# Altium schematic sheet Prometheus.SchDoc
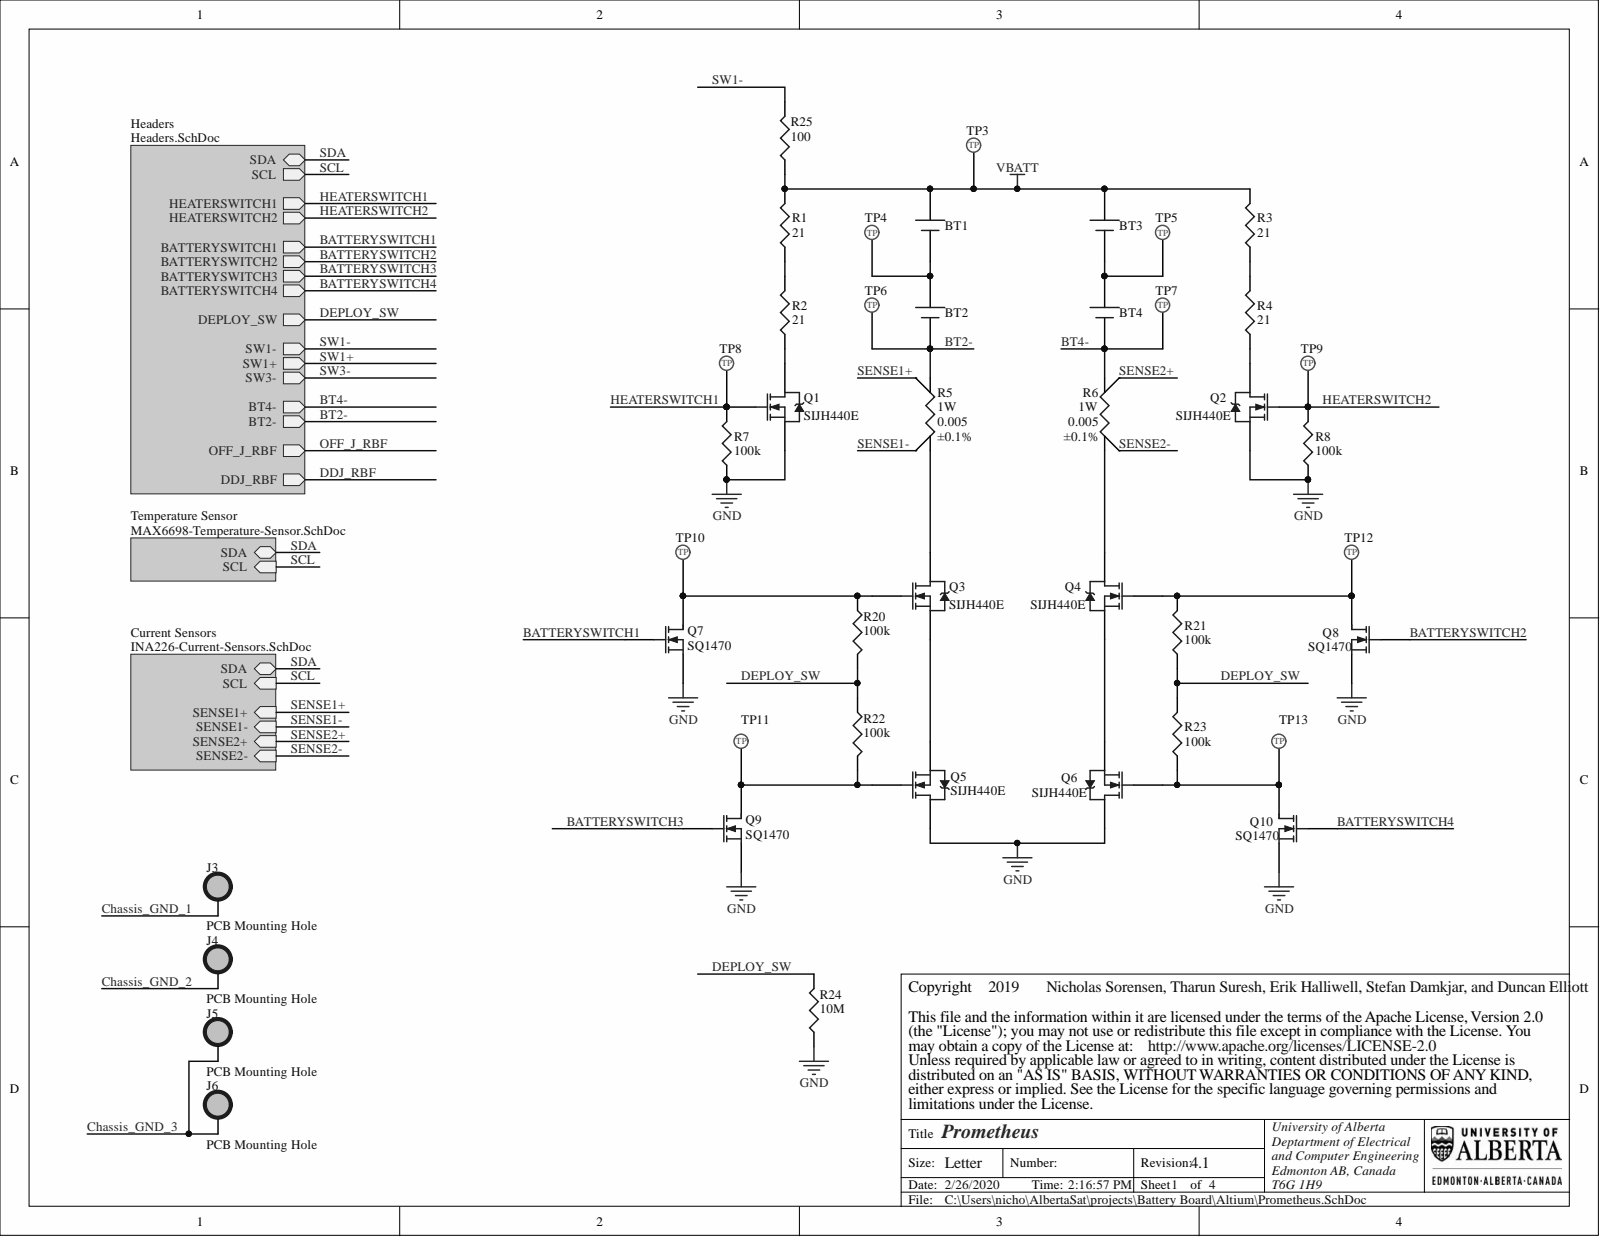
[diagram p0: Prometheus.SchDoc]

## Parts
BT1  NCR18650PF  [ORB-PROTECTED18650]  (value not drawn)
BT2  NCR18650PF  [ORB-PROTECTED18650]  (value not drawn)
BT3  NCR18650PF  [ORB-PROTECTED18650]  (value not drawn)
BT4  NCR18650PF  [ORB-PROTECTED18650]  (value not drawn)
J3  PCB Mounting Hole  [PCB Mounting Hole]
J4  PCB Mounting Hole  [PCB Mounting Hole]
J5  PCB Mounting Hole  [PCB Mounting Hole]
J6  PCB Mounting Hole  [PCB Mounting Hole]
Q1  SIJH440E  [VSH-POWERPAK8X8L]
Q2  SIJH440E  [VSH-POWERPAK8X8L]
Q3  SIJH440E  [VSH-POWERPAK8X8L]
Q4  SIJH440E  [VSH-POWERPAK8X8L]
Q5  SIJH440E  [VSH-POWERPAK8X8L]
Q6  SIJH440E  [VSH-POWERPAK8X8L]
Q7  SQ1470  [VSH-SQ1470AEH]
Q8  SQ1470  [VSH-SQ1470AEH]
Q9  SQ1470  [VSH-SQ1470AEH]
Q10  SQ1470  [VSH-SQ1470AEH]
R1  value 21  [VSH-CPF1]  (hidden comment: Res3)
R2  value 21  [VSH-CPF1]  (hidden comment: Res3)
R3  value 21  [VSH-CPF1]  (hidden comment: Res3)
R4  value 21  [VSH-CPF1]  (hidden comment: Res3)
R5  value 0.005  Power - Rated: 1W  Tolerance: ±0.1%  [VSH-2512R4B]  (hidden comment: Res Sense)
R6  value 0.005  Power - Rated: 1W  Tolerance: ±0.1%  [VSH-2512R4B]  (hidden comment: Res Sense)
R7  value 100k  [PANA-ERJ6EN]  (hidden comment: Res3)
R8  value 100k  [PANA-ERJ6EN]  (hidden comment: Res3)
R20  value 100k  [PANA-ERJ6EN]  (hidden comment: Res3)
R21  value 100k  [PANA-ERJ6EN]  (hidden comment: Res3)
R22  value 100k  [PANA-ERJ6EN]  (hidden comment: Res3)
R23  value 100k  [PANA-ERJ6EN]  (hidden comment: Res3)
R24  value 10M  [VSH-0603MCT]  (hidden comment: Res3)
R25  value 100  [VSH-0805MCU]  (hidden comment: Res3)
TP3  5017  [KS-5017]  (value not drawn)
TP4  5017  [KS-5017]  (value not drawn)
TP5  5017  [KS-5017]  (value not drawn)
TP6  5017  [KS-5017]  (value not drawn)
TP7  5017  [KS-5017]  (value not drawn)
TP8  5017  [KS-5017]  (value not drawn)
TP9  5017  [KS-5017]  (value not drawn)
TP10  5017  [KS-5017]  (value not drawn)
TP11  5017  [KS-5017]  (value not drawn)
TP12  5017  [KS-5017]  (value not drawn)
TP13  5017  [KS-5017]  (value not drawn)

## Nets
GND: Q1.3 Q2.3 Q5.2 Q6.2 Q7.3 Q8.3 Q9.3 Q10.3 R7.1 R8.1 R24.1
VBATT: BT1.1 BT3.1 R1.2 R3.2 R25.1 TP3.1
BATTERYSWITCH1: Q7.2
BATTERYSWITCH2: Q8.2
BATTERYSWITCH3: Q9.2
BATTERYSWITCH4: Q10.2
BT2-: BT2.2 R5.2 TP6.1
BT4-: BT4.2 R6.1 TP7.1
Chassis_GND_1: J3.1
Chassis_GND_2: J4.1
Chassis_GND_3: J5.1 J6.1
DEPLOY_SW: R20.2 R21.1 R22.1 R23.2 R24.2
HEATERSWITCH1: Q1.1 R7.2 TP8.1
HEATERSWITCH2: Q2.1 R8.2 TP9.1
SENSE1+: R5.3
SENSE1-: R5.4
SENSE2+: R6.4
SENSE2-: R6.3
SW1-: R25.2
NetBT1_2: BT1.2 BT2.1 TP4.1
NetBT3_2: BT3.2 BT4.1 TP5.1
NetQ1_2: Q1.2 R2.1
NetQ2_2: Q2.2 R4.1
NetQ3_1: Q3.1 Q7.1 R20.1 TP10.1
NetQ3_2: Q3.2 R5.1
NetQ3_3: Q3.3 Q5.3
NetQ4_1: Q4.1 Q8.1 R21.2 TP12.1
NetQ4_2: Q4.2 R6.2
NetQ4_3: Q4.3 Q6.3
NetQ5_1: Q5.1 Q9.1 R22.2 TP11.1
NetQ6_1: Q6.1 Q10.1 R23.1 TP13.1
NetR1_1: R1.1 R2.2
NetR3_1: R3.1 R4.2
DDJ_RBF:
OFF_J_RBF:
SCL:
SDA:
SW1+:
SW3-:

## Library data of the parts
BT1-BT4 = PBT-ORB-00001: 18650 Battery-Panasonic Protected 2900mAh NCR18650PF Li-ion inside-10 Amp Dual Protection; Battery Cell Size: 18650 Protected; Capacity: 2.9Ah; Database Table Name: Orbtronic; Index: 1; Voltage - Rated: 3.6V; Component Kind: Standard; Designator: BT?; LatestRevisionDate: 5/24/2019; LatestRevisionNote: ...; Library Name: Database.DbLib; Manufacturer Part Number: BRB-2900; Part Number: PBT-ORB-00001; Published: 5/24/2019; Supplier 1: Orbtronic; Supplier Link: #https://www.orbtronic.com/batteries-chargers/protected-panasonic-18650-li-ion-battery-cell-ncr18650-protection-made-in-japan-top-button#; Supplier Part Number 1: BRB-2900; Publisher: AlbertaSat
Q1-Q6 = PQ-VSH-00270: NMOS-2; Manufacturer Part Number: SIJH440E-T1-GE3; Database Table Name: Vishay; Index: 270; Note: Pin 2 is electrically connected to pin 4.; Component Kind: Standard; ComponentLink1Description: Manufacturer Link; ComponentLink1URL: http://www.irf.com/; DatasheetVersion: PD-9.814; Designator: Q?; LatestRevisionDate: 2019-05-24; LatestRevisionNote: ...; Library Name: Database.DbLib; PackageDescription: TO, Thru-Hole, Vertical, Heatsink Mounted; 3 In-Line Leads; Pitch 2.54 mm; PackageReference: VSH-POWERPAK8X8L; PackageVersion: Feb-1997; Part Number: PQ-VSH-00270; Published: 2019-05-24; Simulation: IRF1010; Supplier 1: Digi-key; Supplier 2: Mouser; Supplier Link: #https://www.digikey.ca/product-detail/en/vishay-siliconix/SIJH440E-T1-GE3/SIJH440E-T1-GE3CT-ND/6709032#; Supplier Part Number 1: SIJH440E-T1-GE3CT-ND; Supplier Part Number 2: 78-SIJH440E-T1-GE3; Publisher: AlbertaSat
Q7-Q10 = PQ-VSH-00227: MOSFET N-CH 30V 2.8A SC70; Manufacturer Part Number: SQ1470AEH-T1_GE3; Database Table Name: Vishay; Index: 227; Applications: Automotive; Component Kind: Standard; Designator: Q?; LatestRevisionDate: 7/12/2017; LatestRevisionNote: ...; Library Name: Database.DbLib; PackageDescription: SOT23, 6-Leads, Body 2.00x2.10mm, Pitch 0.65mm, IPC Low Density; PackageReference: VSH-SOT363; PackageVersion: 1995/1996; Part Number: PQ-VSH-00227; Published: 7/12/2017; Simulation: NMOS; Supplier 1: Digi-key; Supplier 2: Mouser; Supplier Link: #https://www.digikey.ca/product-detail/en/vishay-siliconix/SQ1470AEH-T1_GE3/SQ1470AEH-T1_GE3CT-ND/6595360#; Supplier Part Number 1: SQ1470AEH-T1_GE3CT-ND; Supplier Part Number 2: 78-SQ1470AEH-T1_GE3; Publisher: AlbertaSat
R1-R4 = PR-VSH-00269: 21 Ohms ±1% 1W Through Hole Resistor Axial Flame Proof, Moisture Resistant, Safety Metal Film; Manufacturer Part Number: CPF121R000FKEE6; Database Table Name: Vishay; Index: 269; Temperature Coefficient: ±100ppm/°C; Applications: Automotive; Component Kind: Standard; Designator: R?; LatestRevisionDate: 3/27/2019; LatestRevisionNote: ...; Library Name: Database.DbLib; PackageDescription: RESISTOR METAL FILM 1W 21.0 OHM; PackageReference: VSH-CPF1; Part Number: PR-VSH-00269; Power - Rated: 1W; Published: 3/27/2019; Signal Integrity: Res; Simulation: RESISTOR; Supplier 1: Digi-key; Supplier Link: https://www.digikey.ca/products/en/resistors/through-hole-resistors/53?k=cpf1&k=&pkeyword=cpf1&sv=0&pv1989=0&pv2085=u21+Ohms&sf=0&FV=ffe00035&quantity=&ColumnSort=0&page=1&stock=1&pageSize=25; Supplier Part Number 1: CPF21.0CCT-ND; Tolerance: ±1%; Publisher: AlbertaSat
R5 R6 = PR-VSH-00234: RES SMD 0.005 OHM 0.1% 1W 2512; Manufacturer Part Number: Y14870R00500B9R; Database Table Name: Vishay; Index: 234; Temperature Coefficient: ±15ppm/°C; Applications: Automotive; Component Kind: Standard; Designator: R?; LatestRevisionDate: 2017-07-12; LatestRevisionNote: ...; Library Name: Database.DbLib; PackageReference: VSH-2512R4B; Part Number: PR-VSH-00234; Published: 2017-07-12; Supplier 1: Digi-key; Supplier 2: Mouser; Supplier Link: http://www.digikey.ca/product-search/en?keywords=804-1043-1-ND; Supplier Part Number 1: 804-1043-1-ND; Supplier Part Number 2: 71-CSM25120R0050BST; Publisher: AlbertaSat
R7 R8 R20-R23 = 18749: RES SMD 100K OHM 1% 1/8W 0805; Manufacturer Part Number: ERJ-6ENF1003V; Database Table Name: Panasonic; Power: 0.125W, 1/8W; Temperature Coefficient: ±100ppm/°C; Component Kind: Standard; Designator: R?; LatestRevisionDate: 29-May-2009; LatestRevisionNote: IPC-7351 Footprint Added.; Library Name: Database.DbLib; PackageDescription: Chip Resistor, 2-Leads, Body 2.00x1.25mm, IPC Low Density; PackageReference: 0805 (2012 Metric); Part Number: 18749; Published: 8-Jun-2000; Signal Integrity: Res; Simulation: RESISTOR; Supplier 1: Digi-Key; Supplier Link: http://www.digikey.ca/product-search/en?keywords=P100KCCT-ND; Supplier Part Number 1: P100KCCT-ND; Tolerance: ±1%; Publisher: Altium Limited
R24 = PR-VSH-00224: RES SMD 10M OHM 1% 1/8W 0603; Manufacturer Part Number: MCT06030C1005FP500; Database Table Name: Vishay; Index: 224; Temperature Coefficient: ±50ppm/°C; Applications: General Purpose; Component Kind: Standard; Designator: R?; LatestRevisionDate: 3/14/2016; LatestRevisionNote: ...; Library Name: Database.DbLib; PackageDescription: Chip Resistor, 2-Leads, Body 1.55x0.85mm, IPC Low Density; PackageReference: 0603 (1608 Metric); Part Number: PR-VSH-00224; Power - Rated: 1/8W; Published: 3/14/2016; Signal Integrity: Res; Simulation: RESISTOR; Supplier 1: Digi-key; Supplier Link: http://www.digikey.ca/product-search/en?keywords=MCT0603-10.0M-CFCT-ND; Supplier Part Number 1: MCT0603-10.0M-CFCT-ND; Tolerance: ±1%; Publisher: AlbertaSat
R25 = PR-VSH-00029: RES SMD 100 OHM 1% 1/5W 0805; Manufacturer Part Number: MCU08050C1000FP500; Database Table Name: Vishay; Index: 29; Temperature Coefficient: ±50ppm/°C; Applications: General Purpose; Component Kind: Standard; Designator: R?; LatestRevisionDate: 3/14/2016; LatestRevisionNote: ...; Library Name: Database.DbLib; PackageDescription: Chip Resistor, 2-Leads, Body 2.00x1.25mm, IPC Low Density; PackageReference: 0805 (2012 Metric); Part Number: PR-VSH-00029; Power - Rated: 1/5W; Published: 3/14/2016; Signal Integrity: Res; Simulation: RESISTOR; Supplier 1: Digi-key; Supplier Link: http://www.digikey.ca/product-search/en?keywords=MCU0805-100-CFCT-ND; Supplier Part Number 1: MCU0805-100-CFCT-ND; Tolerance: ±1%; Publisher: AlbertaSat
TP3-TP9 TP11-TP13 = PTP-KS-00001: PC TEST POINT MINI SMD; Database Table Name: Keystone; Index: 1; Component Kind: Standard; LatestRevisionDate: 3/15/2016; LatestRevisionNote: ...; Library Name: Database.DbLib; Manufacturer Part Number: 5017; PackageDescription: Testpoint Lug Mini SMD 27X10X152; PackageReference: KS-5017; Part Number: PTP-KS-00001; Published: 3/15/2016; Supplier 1: Digi-key; Supplier 2: Newark; Supplier 3: Mouser; Supplier Link: http://www.digikey.ca/product-detail/en/keystone-electronics/5017/36-5017TR-ND/2217304; Supplier Part Number 1: 36-5017CT-ND; Publisher: AlbertaSat
TP10 = PTP-KS-00001: 3; Database Table Name: Keystone; Index: 1; Component Kind: Standard; LatestRevisionDate: 3/15/2016; LatestRevisionNote: ...; Library Name: Database.DbLib; Manufacturer Part Number: 5017; PackageDescription: Testpoint Lug Mini SMD 27X10X152; PackageReference: KS-5017; Part Number: PTP-KS-00001; Published: 3/15/2016; Supplier 1: Digi-key; Supplier 2: Newark; Supplier 3: Mouser; Supplier Link: http://www.digikey.ca/product-detail/en/keystone-electronics/5017/36-5017TR-ND/2217304; Supplier Part Number 1: 36-5017CT-ND; Publisher: AlbertaSat
J3-J6 = PCB Mounting Hole: Component Kind: Standard; Library Name: Custom-Prometheus.SchLib
